# Altium schematic sheet NXP NXPhlite-CAN ETHERNET 3.0RC18.SchDoc
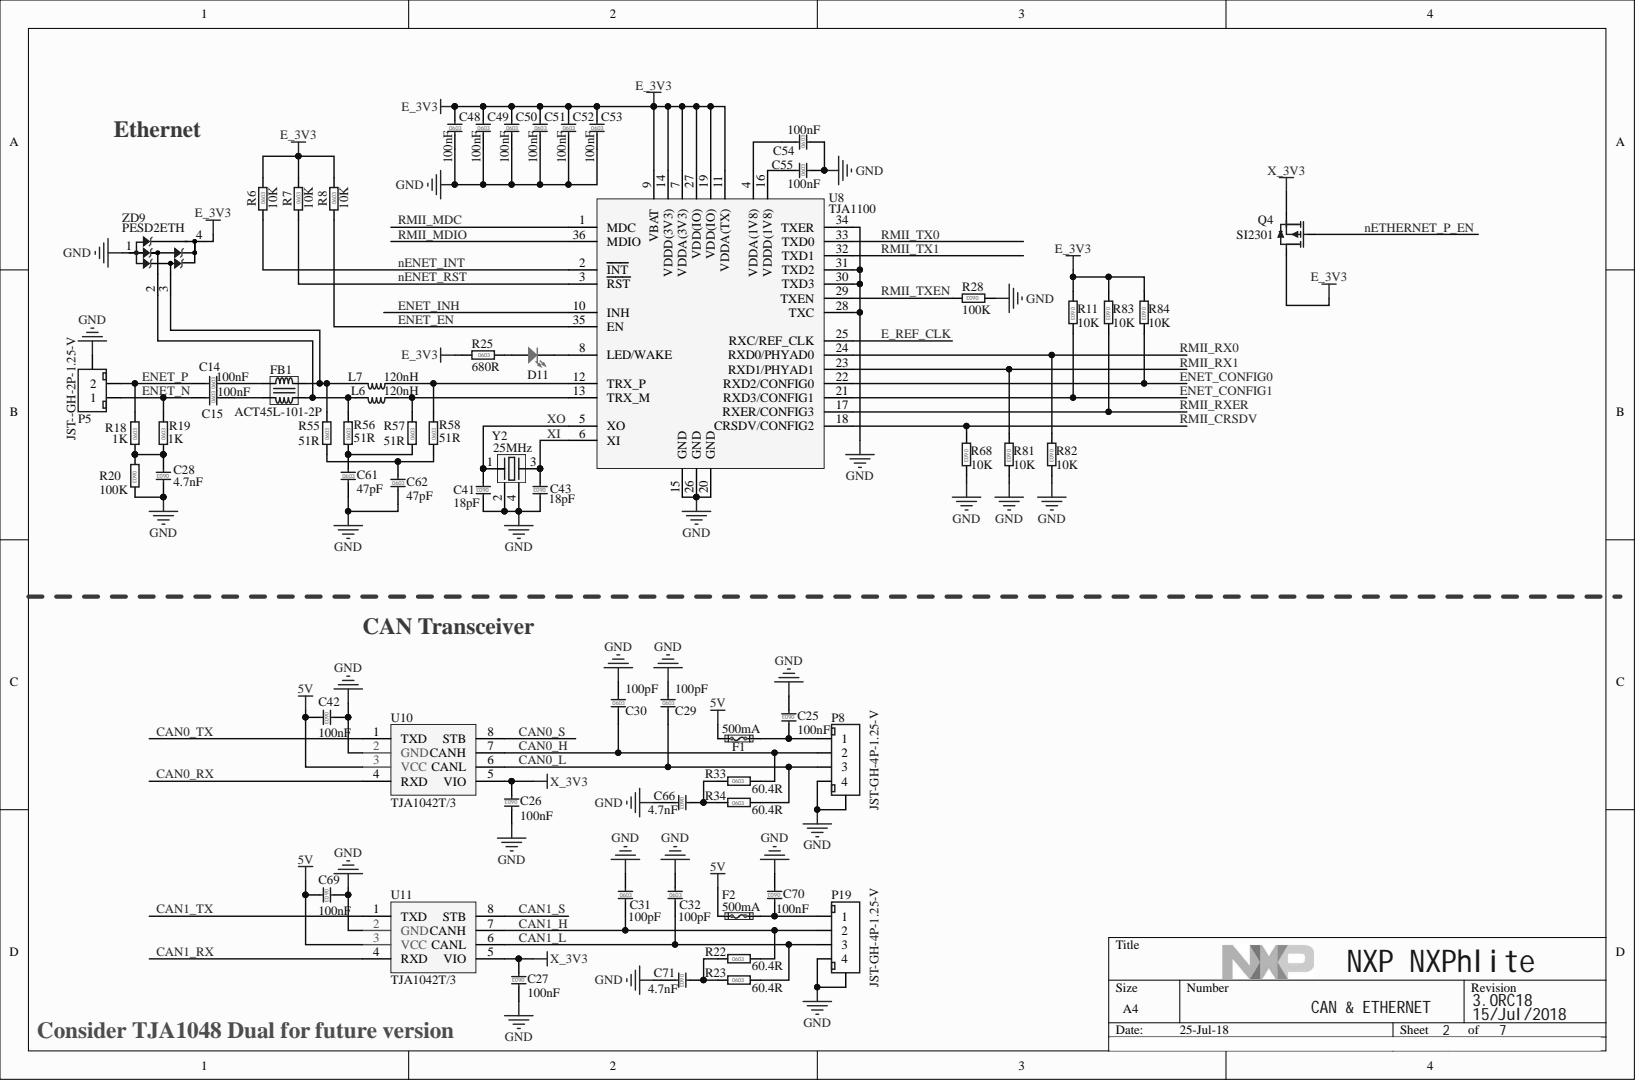
[diagram p0: NXP NXPhlite-CAN ETHERNET 3.0RC18.SchDoc]

## Parts
C14  100nF  [0603C]
C15  100nF  [0603C]
C25  100nF  [0603C]
C26  100nF  [0603C]
C27  100nF  [0603C]
C28  4.7nF  [0603C]
C29  100pF  [0603C]
C30  100pF  [0603C]
C31  100pF  [0603C]
C32  100pF  [0603C]
C41  18pF  [0603C]
C42  100nF  [0603C]
C43  18pF  [0603C]
C48  100nF  [0603C]
C49  100nF  [0603C]
C50  100nF  [0603C]
C51  100nF  [0603C]
C52  100nF  [0603C]
C53  100nF  [0603C]
C54  100nF  [0603C]
C55  100nF  [0603C]
C61  47pF  [0603C]
C62  47pF  [0603C]
C66  4.7nF  [0603C]
C69  100nF  [0603C]
C70  100nF  [0603C]
C71  4.7nF  [0603C]
D11  LED G  [0603LED_ÂÌ]  (value not drawn)
F1  500mA  [0805FUSE]
F2  500mA  [0805FUSE]
FB1  ACT45L-101-2P  [ACT45B]
L6  120nH  [0603L]
L7  120nH  [0603L]
P5  JST--GH-2P-1.25-V  [JST-2P-1.25]
P8  JST-GH-4P-1.25-V  [JST-4P-1.25]
P19  JST-GH-4P-1.25-V  [JST-4P-1.25]
Q4  SI2301  [SOT-23]
R6  10K  [0603R]
R7  10K  [0603R]
R8  10K  [0603R]
R11  10K  [0603R]
R18  1K  [0603R]
R19  1K  [0603R]
R20  100K  [0603R]
R22  60.4R  [0603R]
R23  60.4R  [0603R]
R25  680R  [0603R]
R28  100K  [0603R]
R33  60.4R  [0603R]
R34  60.4R  [0603R]
R55  51R  [0603R]
R56  51R  [0603R]
R57  51R  [0603R]
R58  51R  [0603R]
R68  10K  [0603R]
R81  10K  [0603R]
R82  10K  [0603R]
R83  10K  [0603R]
R84  10K  [0603R]
U8  TJA1100  [QFN36(TJA1100)]
U10  TJA1042T/3  [SO-8]
U11  TJA1042T/3  [SO-8]
Y2  25MHz  [NX3225SA]
ZD9  PESD2ETH  [SOT-143A]

## Nets
5V: C42.2 C69.2 F1.1 F2.1 U10.3(VCC) U11.3(VCC)
E_3V3: C48.1 C49.1 C50.1 C51.1 C52.1 C53.1 Q4.3 R6.1 R7.1 R8.1 R11.2 R25.2 R83.2 R84.2 U8.7(VDDA(3V3)) U8.9(VBAT) U8.11(VDDA(TX)) U8.14(VDDD(3V3)) U8.19(VDD(IO)) U8.27(VDD(IO)) ZD9.4
GND: C25.2 C26.1 C27.1 C28.1 C29.2 C30.2 C31.2 C32.2 C41.1 C42.1 C43.1 C48.2 C49.2 C50.2 C51.2 C52.2 C53.2 C54.2 C55.2 C61.2 C62.2 C66.2 C69.1 C70.2 C71.2 P5.0 P8.0 P8.4 P19.0 P19.4 R20.1 R28.2 R68.1 R81.1 R82.1 U8.15(GND) U8.20(GND) U8.26(GND) U8.28(TXC) U8.30(TXD3) U8.31(TXD2) U8.34(TXER) U10.2(GND) U11.2(GND) Y2.2 Y2.4 ZD9.1
X_3V3: C26.2 C27.2 Q4.2 U10.5(VIO) U11.5(VIO)
CAN0_H: C30.1 P8.2 R33.2 U10.7(CANH)
CAN0_L: C29.1 P8.3 R34.2 U10.6(CANL)
CAN0_RX: U10.4(RXD)
CAN0_S: U10.8(STB)
CAN0_TX: U10.1(TXD)
CAN1_H: C31.1 P19.2 R22.2 U11.7(CANH)
CAN1_L: C32.1 P19.3 R23.2 U11.6(CANL)
CAN1_RX: U11.4(RXD)
CAN1_S: U11.8(STB)
CAN1_TX: U11.1(TXD)
E_REF_CLK: U8.25(RXC/REF_CLK)
ENET_CONFIG0: R84.1 U8.22(RXD2/CONFIG0)
ENET_CONFIG1: R11.1 U8.21(RXD3/CONFIG1)
ENET_EN: R8.2 U8.35(EN)
ENET_INH: U8.10(INH)
ENET_N: C15.1 P5.1 R19.2
ENET_P: C14.1 P5.2 R18.2
nENET_INT: R6.2 U8.2(INT)
nENET_RST: R7.2 U8.3(RST)
nETHERNET_P_EN: Q4.1
RMII_CRSDV: R68.2 U8.18(CRSDV/CONFIG2)
RMII_MDC: U8.1(MDC)
RMII_MDIO: U8.36(MDIO)
RMII_RX0: R82.2 U8.24(RXD0/PHYAD0)
RMII_RX1: R81.2 U8.23(RXD1/PHYAD1)
RMII_RXER: R83.1 U8.17(RXER/CONFIG3)
RMII_TX0: U8.33(TXD0)
RMII_TX1: U8.32(TXD1)
RMII_TXEN: R28.1 U8.29(TXEN)
XI: C43.2 U8.6(XI) Y2.3
XO: C41.2 U8.5(XO) Y2.1
NetC14_2: C14.2 FB1.4
NetC15_2: C15.2 FB1.3
NetC25_1: C25.1 F1.2 P8.1
NetC28_2: C28.2 R18.1 R19.1 R20.2
NetC54_1: C54.1 U8.4(VDDA(1V8))
NetC55_1: C55.1 U8.16(VDDD(1V8))
NetC61_1: C61.1 R56.1 R57.1
NetC62_1: C62.1 R55.1 R58.1
NetC66_1: C66.1 R33.1 R34.1
NetC70_1: C70.1 F2.2 P19.1
NetC71_1: C71.1 R22.1 R23.1
NetD11_1: D11.1 R25.1
NetD11_2: D11.2 U8.8(LED/WAKE)
NetFB1_1: FB1.1 L7.1 R55.2 ZD9.3
NetFB1_2: FB1.2 L6.1 R56.2 ZD9.2
NetL6_2: L6.2 R57.2 U8.13(TRX_M)
NetL7_2: L7.2 R58.2 U8.12(TRX_P)

## Library data of the parts
C14 C15 C25-C27 C42 C48-C55 C69 C70 = Cap_0603: CAP CER 0.1uF 16V 10% X7R AEC-Q200 0603; Manufacturer: MURATA; Manufacturer PN: GCM188R71C104KA37D; Agile PN: 150-79338; Component Kind: Standard; Library Name: LZ SchLib V1.0.SchLib
C28 C66 C71 = Cap_0603: CAP CER 4700pF 25V 5% C0G 0603; Manufacturer: KEMET; Manufacturer PN: C0603C472J3GACTU; Agile PN: 150-80026; Component Kind: Standard; Library Name: LZ SchLib V1.0.SchLib
C29-C32 = Cap_0603: CAP CER 100PF 16V 1% COG 0603; Manufacturer: KEMET; Manufacturer PN: C0603C101F4GAC; Agile PN: 150-77436; Component Kind: Standard; Library Name: LZ SchLib V1.0.SchLib
C41 C43 = Cap_0603: CAP CER 18PF 25V 5% NP0 0603; Manufacturer: KEMET; Manufacturer PN: C0603C180J3GACTU; Agile PN: 150-75560; Component Kind: Standard; Library Name: LZ SchLib V1.0.SchLib
C61 C62 = Cap_0603: CAP CER 47PF 50V 1% C0G 0603; Manufacturer: AVX; Manufacturer PN: 06035A470FAT2A; Agile PN: 150-75839; Component Kind: Standard; Library Name: LZ SchLib V1.0.SchLib
D11 = LED G: LED GRN SGL 25MA SMT 0603; Manufacturer: DIALIGHT; Manufacturer PN: 598-8070-107F; Agile PN: 370-76672; Component Kind: Standard; Library Name: LZ SchLib V1.0.SchLib
F1 F2 = Fuse1: FUSE FAST 0.5A 32V SMT 0805; Manufacturer: Littlelfuse; Manufacturer PN: 0805L020YR; Agile PN: TBD; Component Kind: Standard; Library Name: LZ SchLib V1.0.SchLib
FB1 = ACT45L-101-2P: Manufacturer: TDK; Manufacturer PN: ACT45B-101-2P-TL003; Agile PN: TBD; Component Kind: Standard; Library Name: NXP NXPhlite V3.2.SCHLIB
L6 L7 = Inductor_1: FIXED IND 120NH 180MA 1.3 OHM; Manufacturer: Murata; Manufacturer PN: LQW18ANR12G00D; Agile PN: TBD; Component Kind: Standard; Library Name: LZ SchLib V1.0.SchLib
P5 = XH2.54_2P: CONN HEADER GH TOP 2POS 1.25MM; Manufacturer: JST Sales America Inc; Manufacturer PN: BM02B-GHS-TBT(LF)(SN)(N); Agile PN: TBD; Component Kind: Standard; Library Name: NXP NXPhlite V3.0.SCHLIB
P8 P19 = XH2.54_4P: CONN HEADER GH TOP 4POS 1.25MM; Manufacturer: JST Sales America Inc; Manufacturer PN: BM04B-GHS-TBT(LF)(SN)(N); Agile PN: TBD; Component Kind: Standard; Library Name: LANDZO flight controller V1.1.SCHLIB
Q4 = MOSFET-P: MOSFET P-CH 20V 3.1A SOT23-3; Manufacturer: VISHAY INTERTECHNOLOGY; Manufacturer PN: Si2301CDS; Agile PN: TBD; Component Kind: Standard; Simulation: PMOS; Library Name: NXP NXPhlite V3.2.SCHLIB
R6-R8 R11 R68 R81-R84 = Res_0603: RES MF 10.0K 1/10W 1% 0603; Manufacturer: Yageo; Manufacturer PN: RC0603FR-0710KL; Agile PN: 470-75422; Component Kind: Standard; Library Name: LZ SchLib V1.0.SchLib
R18 R19 = Res_0603: RES MF 1.00K 1/8W 1% AEC-Q200 0603; Manufacturer: KOA SPEER; Manufacturer PN: RK73H1JTTD1001F; Agile PN: 470-81616; Component Kind: Standard; Library Name: LZ SchLib V1.0.SchLib
R20 R28 = Res_0603: RES MF 100K 1/10W 1% AEC-Q200 0603; Manufacturer: KOA SPEER; Manufacturer PN: RK73H1JTTD1003F; Agile PN: 470-81842; Component Kind: Standard; Library Name: LZ SchLib V1.0.SchLib
R22 R23 R33 R34 = Res_0603: RES MF 60.4 OHM 1/10W 1% AEC-Q200 0603; Manufacturer: KOA SPEER; Manufacturer PN: RK73H1JTTD60R4F; Agile PN: 470-81807; Component Kind: Standard; Library Name: LZ SchLib V1.0.SchLib
R25 = Res_0603: RES MF 680 OHM 1/8W 1% AEC-Q200 0603; Manufacturer: KOA SPEER; Manufacturer PN: RK73H1JTTD6800F; Agile PN: 470-81586; Component Kind: Standard; Library Name: LZ SchLib V1.0.SchLib
R55-R58 = Res_0603: RES MF 51.0 OHM 1/8W 1% AEC-Q200 0603; Manufacturer: KOA SPEER; Manufacturer PN: RK73H1JTTD51R0F; Agile PN: 470-81936; Component Kind: Standard; Library Name: LZ SchLib V1.0.SchLib
U8 = TJA1100: IC ETHERNET PHY 3.3 V AEC-Q100 HVQFN36; Manufacturer: NXP SEMICONDUCTORS; Manufacturer PN: TJA1100HNZ; Agile PN: 312-81239; Component Kind: Standard; Library Name: LANDZO flight controller V2.0.SCHLIB
U10 U11 = TJA1042T/3: IC XCVR CAN 1MBIT/S 4.5-5.5V SO8; Manufacturer: NXP SEMICONDUCTORS; Manufacturer PN: TJA1042T/3,118; Agile PN: 312-80409; Component Kind: Standard; Library Name: NXP NXPhlite V3.2.SCHLIB
Y2 = ABM3C_25MHz: XTAL 25MHZ 50PPM 8PF AEC-Q200 SMT; Manufacturer: NDK America, Inc.; Manufacturer PN: NX3225SA-25.000M-STD-CRS-2; Agile PN: 230-78103; Component Kind: Standard; Library Name: LANDZO ESC V2.0.SCHLIB
ZD9 = SP0503BAHT: DIODE ARRAY ESD PROTECTION 5.5V AEC-Q101 SOT143B; Manufacturer: Nexperia; Manufacturer PN: PESD2ETH-AX,215; Agile PN: 480-79666; Component Kind: Standard; Library Name: NXP NXPhlite V3.2.SCHLIB
